# Altium schematic sheet vibe.SchDoc
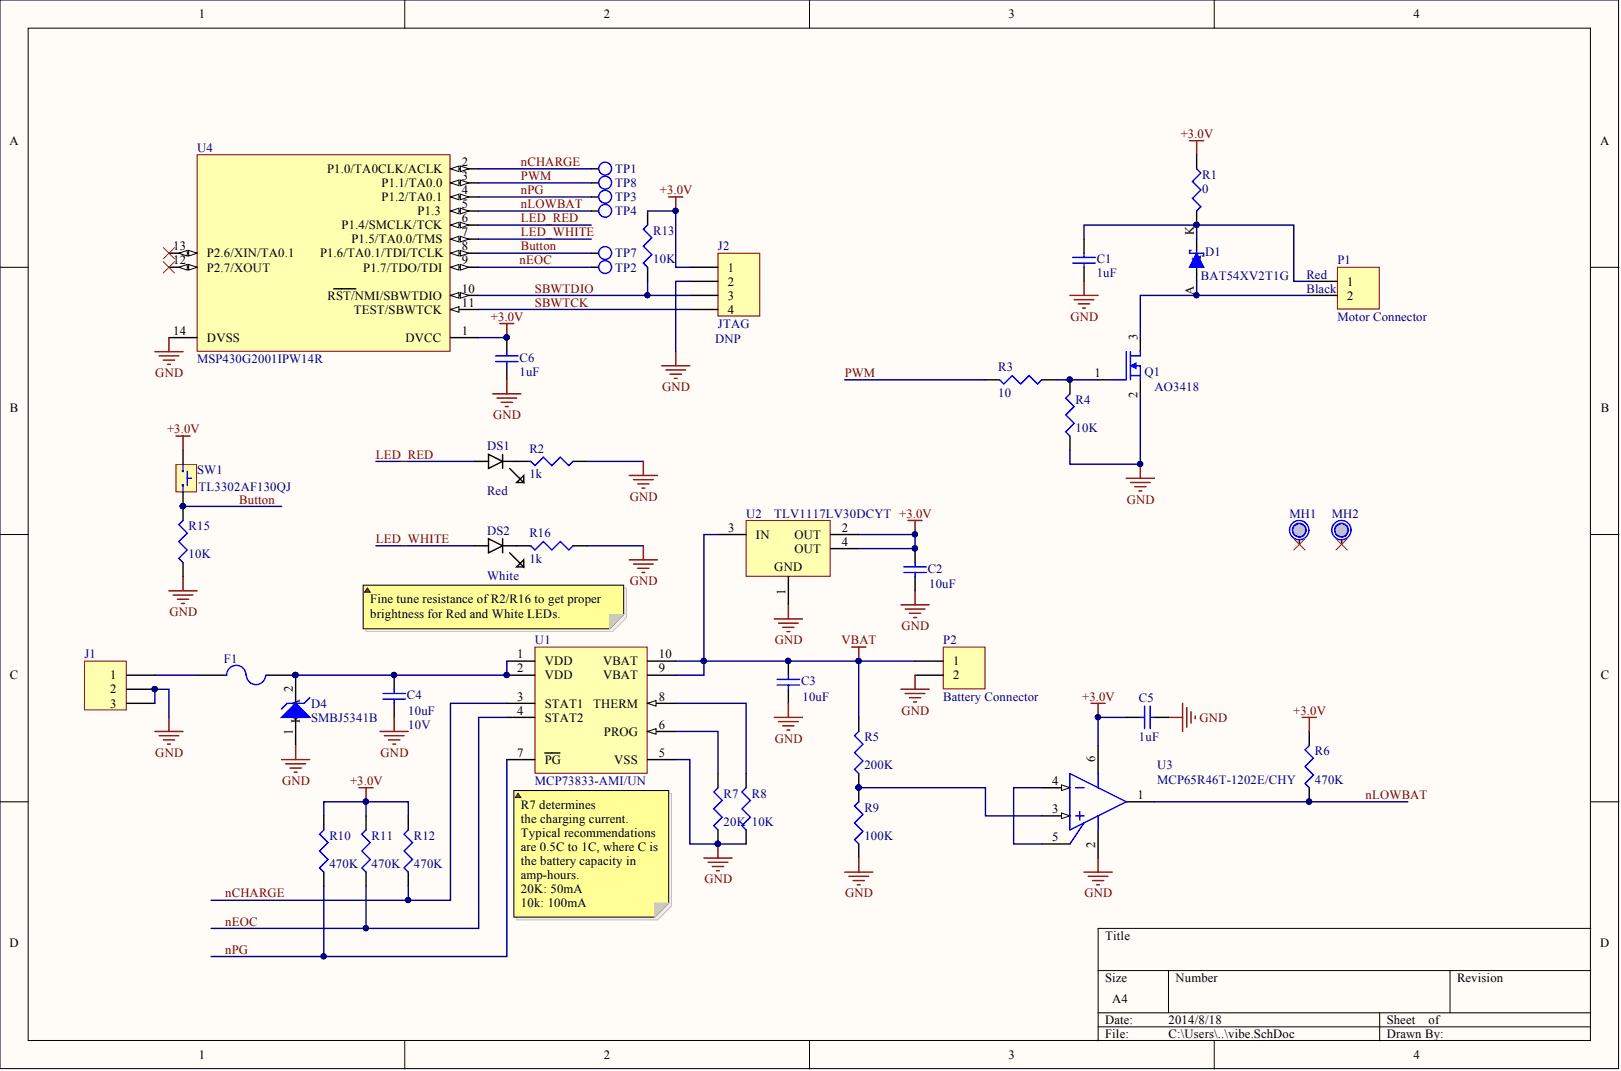
[diagram p0: vibe.SchDoc]

## Parts
C1  value 1uF  [0402]  (hidden comment: Capacitor)
C2  value 10uF  [0603]  (hidden comment: Capacitor)
C3  value 10uF  [0603]  (hidden comment: Capacitor)
C4  value 10uF  Voltage - Rated: 10V  [0603]  (hidden comment: Capacitor)
C5  value 1uF  [0402]  (hidden comment: Capacitor)
C6  value 1uF  [0402]  (hidden comment: Capacitor)
D1  Manufacturer Part Number: BAT54XV2T1G  [SOD-523]
D4  SMBJ5341B  [DIOC4436X24N]
DS1  Red  [LED0603_RED]
DS2  White  [LED0603_White]
F1  (no value)  [FUSE_1210]
J1  Header 3  [PJ1-022]  (value not drawn)
J2  JTAG  Populate: DNP  [HDR1X4]
MH1  Motor Mount Hole  [MountingHole1.6mm]  (value not drawn)  (no BOM)
MH2  Motor Mount Hole  [MountingHole1.6mm]  (value not drawn)  (no BOM)
P1  Motor Connector  [HDR1X2_50mil_Vertical]
P2  Battery Connector  [HDR1X2_50mil_Vertical]
Q1  Manufacturer Part Number: AO3418  [SOT23-3L]  (hidden comment: Name)
R1  value 0  [0402]  (hidden comment: Res3)
R2  value 1k  [0402]  (hidden comment: Res3)
R3  value 10  [0402]  (hidden comment: Res3)
R4  value 10K  [0402]  (hidden comment: Res3)
R5  value 200K  [0402]  (hidden comment: Res3)
R6  value 470K  [0402]  (hidden comment: Res3)
R7  value 20K  [0402]  (hidden comment: Res3)
R8  value 10K  [0402]  (hidden comment: Res3)
R9  value 100K  [0402]  (hidden comment: Res3)
R10  value 470K  [0402]  (hidden comment: Res3)
R11  value 470K  [0402]  (hidden comment: Res3)
R12  value 470K  [0402]  (hidden comment: Res3)
R13  value 10K  [0402]  (hidden comment: Res3)
R15  value 10K  [0402]  (hidden comment: Res3)
R16  value 1k  [0402]  (hidden comment: Res3)
SW1  Manufacturer Part Number: TL3302AF130QJ  [E-Switch TL3302]  (hidden comment: SPST Momentary)
TP1  Testpoint  [TESTPOINT-15/25]  (value not drawn)  (no BOM)
TP2  Testpoint  [TESTPOINT-15/25]  (value not drawn)  (no BOM)
TP3  Testpoint  [TESTPOINT-15/25]  (value not drawn)  (no BOM)
TP4  Testpoint  [TESTPOINT-15/25]  (value not drawn)  (no BOM)
TP7  Testpoint  [TESTPOINT-15/25]  (value not drawn)  (no BOM)
TP8  Testpoint  [TESTPOINT-15/25]  (value not drawn)  (no BOM)
U1  MCP73833-AMI/UN  [MSOP-UN10_L]
U2  TLV1117LV30DCYT  [TI-DCY4_N]
U3  MCP65R46T-1202E/CHY  [SOT-23-CHY6_M]
U4  MSP430G2001IPW14R  [TI-PW14_N]

## Nets
+3.0V: C2.2 C5.2 C6.1 J2.1 R1.1 R6.2 R10.2 R11.2 R12.2 R13.2 SW1.1 U2.2(OUT) U2.4(OUT) U3.6 U4.1(DVCC)
GND: C1.1 C2.1 C3.1 C4.1 C5.1 C6.2 D4.1 J1.2 J1.3 J2.2 P2.2 Q1.2 R2.1 R4.1 R7.1 R8.1 R9.1 R15.1 R16.1 U1.5(VSS) U2.1 U3.2 U4.14(DVSS)
VBAT: C3.2 P2.1 R5.2 U1.9(VBAT) U1.10(VBAT) U2.3(IN)
BUTTON: R15.2 SW1.2 TP7.1 U4.8(P1.6/TA0.1/TDI/TCLK)
LED_RED: DS1.1 U4.6(P1.4/SMCLK/TCK)
LED_WHITE: DS2.1 U4.7(P1.5/TA0.0/TMS)
NCHARGE: R12.1 TP1.1 U1.3(STAT1) U4.2(P1.0/TA0CLK/ACLK)
NEOC: R11.1 TP2.1 U1.4(STAT2) U4.9(P1.7/TDO/TDI)
NLOWBAT: R6.1 TP4.1 U3.1 U4.5(P1.3)
NPG: R10.1 TP3.1 U1.7(PG) U4.4(P1.2/TA0.1)
PWM: R3.2 TP8.1 U4.3(P1.1/TA0.0)
SBWTCK: J2.4 U4.11(TEST/SBWTCK)
SBWTDIO: J2.3 R13.1 U4.10(RST/NMI/SBWTDIO)
NetC1_2: C1.2 D1.K P1.1 R1.2
NetC4_2: C4.2 D4.2 F1.2 U1.1(VDD) U1.2(VDD)
NetD1_A: D1.A P1.2 Q1.3
NetDS1_2: DS1.2 R2.2
NetDS2_2: DS2.2 R16.2
NetF1_1: F1.1 J1.1
NetQ1_1: Q1.1 R3.1 R4.2
NetR5_1: R5.1 R9.2 U3.3
NetR7_2: R7.2 U1.6(PROG)
NetR8_2: R8.2 U1.8(THERM)
NetU3_4: U3.4 U3.5

Not connected: MH1 0; MH2 0; U4 12(P2.7/XOUT) 13(P2.6/XIN/TA0.1)

## Library data of the parts
C1 = Capacitor: CAP CER 1UF 10V 10% X5R 0402; Manufacturer: Murata Electronics North America; Manufacturer Part Number: GRM155R61A105KE15D; Component Kind: Standard; Mounting Type: Surface Mount; Package / Case: 0402 (1005 Metric); RoHS: RoHS Compliant; Supplier: Digi-Key; Supplier Part Number: 490-3890-1-ND; Tolerance: ±10%; Voltage - Rated: 10V; Library Name: MakerBot.SchLib
C2-C4 = Cap Semi: CAP CER 10UF 10V 20% X5R 0603; Manufacturer: Taiyo Yuden; Manufacturer Part Number: LMK107BJ106MALTD; Component Kind: Standard; Library Name: Miscellaneous Devices.IntLib; Mounting Type: Surface Mount; Package / Case: 0603 (1608 Metric); RoHS: RoHS Compliant; Supplier: Digi-Key; Supplier Part Number: 587-2562-1-ND; Temperature Coefficient: X5R; Tolerance: ±20%
  C2 C3: Operating Temperature: -55°C ~ 85°C; Simulation: CAP; Voltage - Rated: 10V
C5 C6 = Cap Semi: CAP CER 1UF 10V 10% X5R 0402; Manufacturer: Murata Electronics North America; Manufacturer Part Number: GRM155R61A105KE15D; Component Kind: Standard; Library Name: Miscellaneous Devices.IntLib; Mounting Type: Surface Mount; Package / Case: 0402 (1005 Metric); RoHS: RoHS Compliant; Simulation: CAP; Supplier: Digi-Key; Supplier Part Number: 490-3890-1-ND; Tolerance: ±10%; Voltage - Rated: 10V
D1 = Schottky Diode Small: DIODE SCHOTTKY 30V 0.2A SOD523; Manufacturer: ON Semiconductor; Component Kind: Standard; Mounting Type: Surface Mount; Package / Case: SC-79, SOD-523; RoHS: RoHS Compliant; Supplier: Digi-Key; Supplier Part Number: BAT54XV2T1GOSCT-ND; Library Name: MakerBot.SchLib
D4 = D Zener: DIODE ZENER 6.2V 5W DO214AA; Manufacturer: Micro Commercial Co; Manufacturer Part Number: SMBJ5341B-TP; Tolerance: ±5%; Component Kind: Standard; Library Name: Miscellaneous Devices.IntLib; Mounting Type: Surface Mount; Package / Case: DO-214AA, SMB; RoHS: RoHS Compliant; Simulation: ZENER; Supplier: Digi-Key; Supplier Part Number: SMBJ5341B-TPMSDKR-ND
DS1 = LED0805: LED SMARTLED 630NM RED 0603 SMD; Manufacturer: OSRAM Opto Semiconductors Inc; Manufacturer Part Number: LS L29K-G1J2-1-Z; Component Kind: Standard; Mounting Type: Surface Mount; Package / Case: 0603 (1608 Metric); RoHS: RoHS Compliant; Supplier: Digi-Key; Supplier Part Number: 475-2506-1-ND; Library Name: MakerBot.SchLib
DS2 = LED0805: LED WHITE HIGH BRIGHT ESS SMD; Manufacturer: Panasonic Electronic Components; Manufacturer Part Number: LNJ037X8ARA; Component Kind: Standard; Mounting Type: Surface Mount; Package / Case: 0603 (1608 Metric); RoHS: RoHS Compliant; Supplier: Digi-Key; Supplier Part Number: LNJ037X8ARACT-ND; Library Name: MakerBot.SchLib
F1 = Fuse 2: PTC RESET 30V .200A SMD 1210; Manufacturer: Littelfuse Inc; Manufacturer Part Number: 1210L020WR; Component Kind: Standard; Library Name: Miscellaneous Devices.IntLib; Mounting Type: Surface Mount; Package / Case: 1210 (3225 Metric), Concave; RoHS: RoHS Compliant; Simulation: FUSE; Supplier: Digi-Key; Supplier Part Number: F3350CT-ND
J1 = Header 3: CONN PWR JACK DC 0.65X2.75MM SMD; Manufacturer: CUI Inc; Voltage - Rated: 16VDC; Component Kind: Standard; Manufacturer Part Number: PJ1-022; Mounting Type: Through Hole, Right Angle; RoHS: RoHS Compliant; Supplier: Digi-Key; Supplier Part Number: CP1-022-ND; Library Name: MakerBot.SchLib
J2 = Header 4_1: Component Kind: Standard; Library Name: MakerBot.SchLib
MH1 MH2 = Chassis_Mount_Plated: Library Name: MakerBot.SchLib
P1 P2 = Header 2H: CONN HEADER 2POS 1.25MM VERT TIN; Manufacturer: Molex Inc; Manufacturer Part Number: 0530470210; Component Kind: Standard; Library Name: Miscellaneous Connectors.IntLib; Mounting Type: Through Hole; RoHS: RoHS Compliant; Supplier: Digi-Key; Supplier Part Number: WM1731-ND
Q1 = NFETSS: MOSFET N-CH 30V 3.8A SOT23; Manufacturer: Alpha & Omega Semiconductor Inc; Component Kind: Standard; Mounting Type: Surface Mount; Package / Case: TO-236-3, SC-59, SOT-23-3; RoHS: RoHS Compliant; Supplier: Digi-Key; Supplier Part Number: 785-1012-1-ND; Library Name: MakerBot.SchLib
R1 = Res3: RES 0.0 OHM 1/16W JUMP 0402; Manufacturer: Samsung Electro-Mechanics America, Inc; Manufacturer Part Number: RC1005J000CS; Component Kind: Standard; Library Name: Miscellaneous Devices.IntLib; Mounting Type: Surface Mount; Package / Case: 0402 (1005 Metric); RoHS: RoHS Compliant; Simulation: RESISTOR; Supplier: Digi-Key; Supplier Part Number: 1276-3480-1-ND
R2 R16 = Res3: RES 1K OHM 1/16W 5% 0402; Manufacturer: Samsung Electro-Mechanics America, Inc; Manufacturer Part Number: RC1005J102CS; Temperature Coefficient: ±100ppm/°C; Component Kind: Standard; Library Name: Miscellaneous Devices.IntLib; Mounting Type: Surface Mount; Package / Case: 0402 (1005 Metric); RoHS: RoHS Compliant; Simulation: RESISTOR; Supplier: Digi-Key; Supplier Part Number: 1276-4376-1-ND; Tolerance: ±5%
R3 = Res3: RES 10 OHM 1/16W 5% 0402; Manufacturer: Samsung Electro-Mechanics America, Inc; Manufacturer Part Number: RC1005J100CS; Temperature Coefficient: ±100ppm/°C; Component Kind: Standard; Library Name: Miscellaneous Devices.IntLib; Mounting Type: Surface Mount; Package / Case: 0402 (1005 Metric); RoHS: RoHS Compliant; Simulation: RESISTOR; Supplier: Digi-Key; Supplier Part Number: 1276-4328-1-ND; Tolerance: ±5%
R4 R8 R13 R15 = Res3: RES 10K OHM 1/16W 1% 0402; Manufacturer: Samsung Electro-Mechanics America, Inc; Manufacturer Part Number: RC1005F103CS; Temperature Coefficient: ±100ppm/°C; Component Kind: Standard; Library Name: Miscellaneous Devices.IntLib; Mounting Type: Surface Mount; Package / Case: 0402 (1005 Metric); RoHS: RoHS Compliant; Simulation: RESISTOR; Supplier: Digi-Key; Supplier Part Number: 1276-3431-1-ND; Tolerance: ±1%
R5 = Res3: RES 200K OHM 1/16W 5% 0402; Manufacturer: Samsung Electro-Mechanics America, Inc; Manufacturer Part Number: RC1005J204CS; Temperature Coefficient: ±100ppm/°C; Component Kind: Standard; Library Name: Miscellaneous Devices.IntLib; Mounting Type: Surface Mount; Package / Case: 0402 (1005 Metric); RoHS: RoHS Compliant; Simulation: RESISTOR; Supplier: Digi-Key; Supplier Part Number: 1276-4431-1-ND; Tolerance: ±5%
R6 R10-R12 = Res3: RES 470K OHM 1/16W 1% 0402; Manufacturer: Samsung Electro-Mechanics America, Inc; Manufacturer Part Number: RC1005F474CS; Temperature Coefficient: ±100ppm/°C; Component Kind: Standard; Library Name: Miscellaneous Devices.IntLib; Mounting Type: Surface Mount; Package / Case: 0402 (1005 Metric); RoHS: RoHS Compliant; Simulation: RESISTOR; Supplier: Digi-Key; Supplier Part Number: 1276-4260-1-ND; Tolerance: ±1%
R7 = Res3: RES 20K OHM 1/16W 5% 0402; Manufacturer: Samsung Electro-Mechanics America, Inc; Manufacturer Part Number: RC1005J203CS; Temperature Coefficient: ±100ppm/°C; Component Kind: Standard; Library Name: Miscellaneous Devices.IntLib; Mounting Type: Surface Mount; Package / Case: 0402 (1005 Metric); RoHS: RoHS Compliant; Simulation: RESISTOR; Supplier: Digi-Key; Supplier Part Number: 1276-4407-1-ND; Tolerance: ±5%
R9 = Res3: RES 100K OHM 1/16W 5% 0402; Manufacturer: Samsung Electro-Mechanics America, Inc; Manufacturer Part Number: RC1005J104CS; Temperature Coefficient: ±100ppm/°C; Component Kind: Standard; Library Name: Miscellaneous Devices.IntLib; Mounting Type: Surface Mount; Package / Case: 0402 (1005 Metric); RoHS: RoHS Compliant; Simulation: RESISTOR; Supplier: Digi-Key; Supplier Part Number: 1276-4424-1-ND; Tolerance: ±5%
SW1 = Switch SPST Momentary: SWITCH TACTILE SPST-NO 0.05A 12V; Manufacturer: E-Switch; Component Kind: Standard; Mounting Type: Surface Mount; RoHS: RoHS Compliant; Supplier: Digi-Key; Supplier Part Number: EG4578CT-ND; Library Name: MakerBot.SchLib
TP1-TP4 TP7 TP8 = Testpoint: Populate: DNP; Library Name: MakerBot.SchLib
U1 = CMP-0133-00182-1: IC LI-ION/LI-POLY CTRLR 10MSOP; Manufacturer: Microchip Technology; Manufacturer Part Number: MCP73833T-AMI/UN; Component Kind: Standard; Library Name: Altium Content Vault; Mounting Type: Surface Mount; Operating Temperature: -40°C ~ 85°C; Package / Case: 10-TFSOP, 10-MSOP (0.118", 3.00mm Width); RoHS: RoHS Compliant; Supplier: Digi-Key; Supplier Part Number: MCP73833T-AMI/UN-ND
U2 = CMP-0395-00509-1: IC REG LDO 3V 1A SOT223; Manufacturer: Texas Instruments; Manufacturer Part Number: TLV1117LV30DCYR; Component Kind: Standard; Library Name: Altium Content Vault; Mounting Type: Surface Mount; Operating Temperature: -40°C ~ 125°C; Package / Case: TO-261-4, TO-261AA; RoHS: RoHS Compliant; Supplier: Digi-Key; Supplier Part Number: 296-30591-1-ND
U3 = CMP-0187-00784-1: IC COMPARATOR 1.2V REF SOT-23-6; Manufacturer: Microchip Technology; Component Kind: Standard; Library Name: Altium Content Vault; Manufacturer Part Number: MCP65R46T-1202E/CHY; Mounting Type: Surface Mount; Operating Temperature: -40°C ~ 125°C; Package / Case: SOT-23-6; RoHS: RoHS Compliant; Supplier: Digi-Key; Supplier Part Number: MCP65R46T-1202E/CHYCT-ND
U4 = CMP-0365-00542-1: IC MCU 16BIT 512B FLASH 14TSSOP; Manufacturer: Texas Instruments; Component Kind: Standard; Library Name: Altium Content Vault; Manufacturer Part Number: MSP430G2001IPW14; Mounting Type: Surface Mount; Package / Case: 14-TSSOP (0.173", 4.40mm Width); RoHS: RoHS Compliant; Supplier: Digi-Key; Supplier Part Number: 296-25828-5-ND
